# Altium schematic sheet DDR3-RAM.SchDoc(DDR3-RAM)
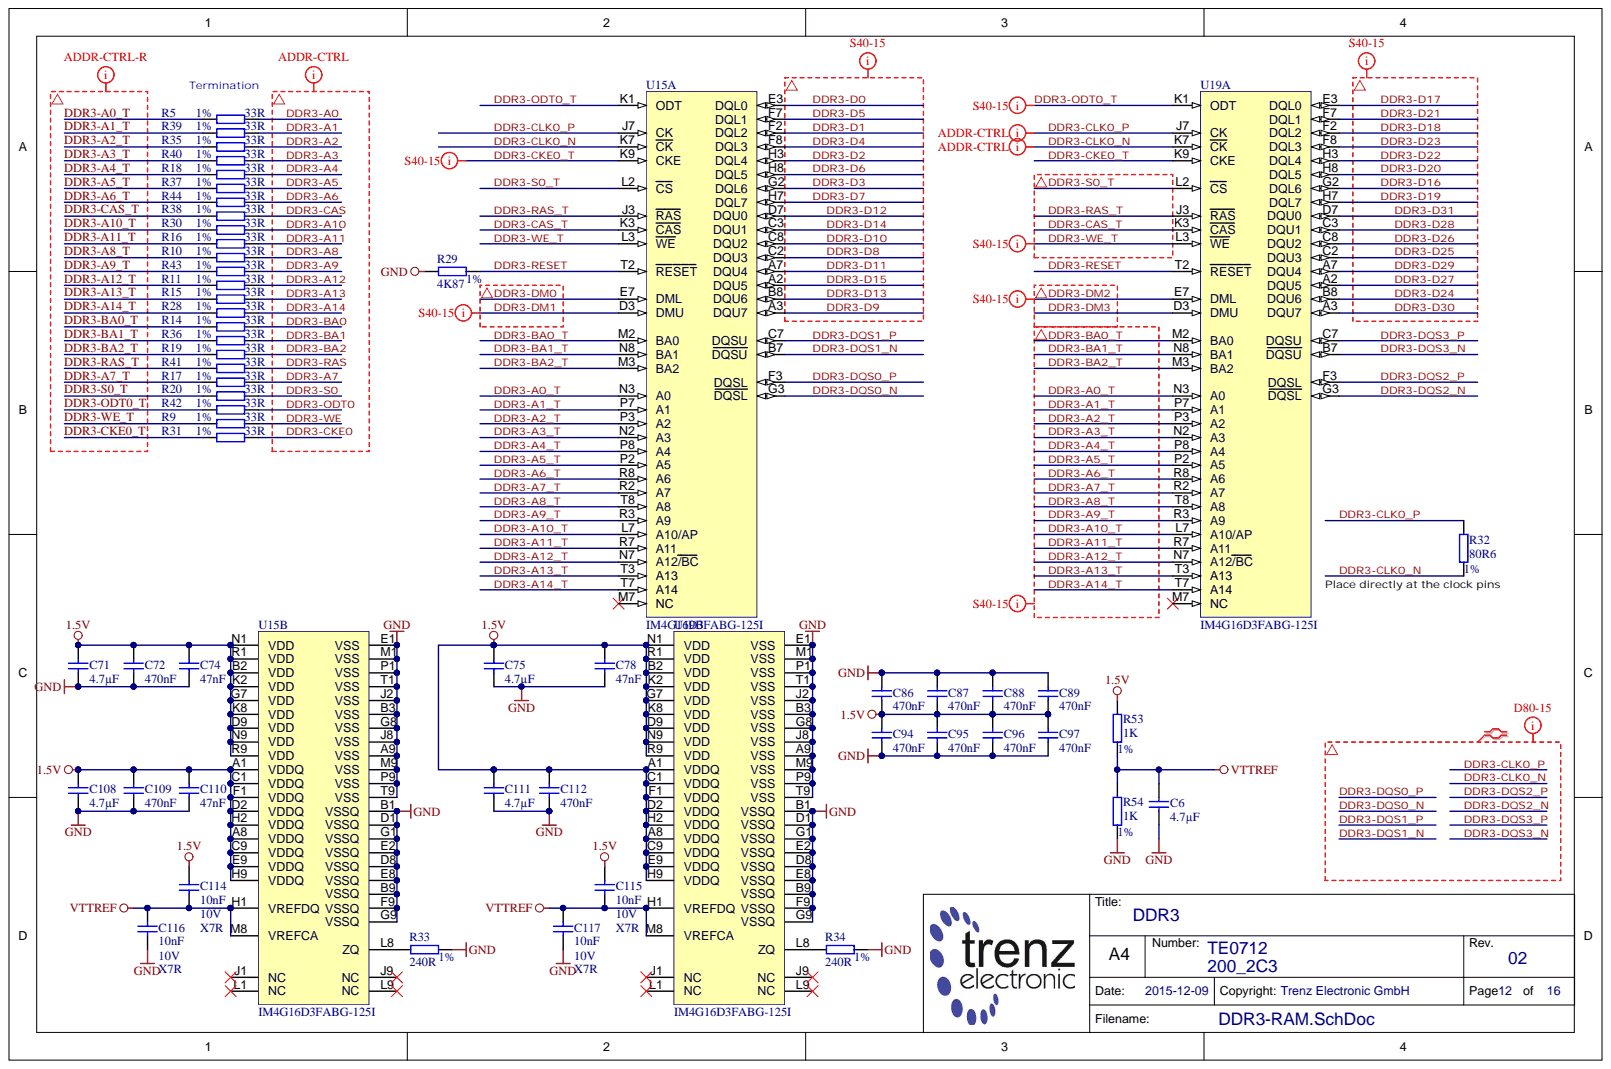
[diagram p0: DDR3-RAM.SchDoc]

## Parts
C6  4.7µF  [CAPC1005X60N]
C71  4.7µF  [CAPC1005X60N]
C72  470nF  [CAPC0603X33N]
C74  47nF  [CAPC0603X33N]
C75  4.7µF  [CAPC1005X60N]
C78  47nF  [CAPC0603X33N]
C86  470nF  [CAPC0603X33N]
C87  470nF  [CAPC0603X33N]
C88  470nF  [CAPC0603X33N]
C89  470nF  [CAPC0603X33N]
C94  470nF  [CAPC0603X33N]
C95  470nF  [CAPC0603X33N]
C96  470nF  [CAPC0603X33N]
C97  470nF  [CAPC0603X33N]
C108  4.7µF  [CAPC1005X60N]
C109  470nF  [CAPC0603X33N]
C110  47nF  [CAPC0603X33N]
C111  4.7µF  [CAPC1005X60N]
C112  470nF  [CAPC0603X33N]
C114  10nF  Voltage: 10V  [CAPC0603X33N]
C115  10nF  Voltage: 10V  [CAPC0603X33N]
C116  10nF  Technology: X7R  Voltage: 10V  [CAPC0603X33N]
C117  10nF  Technology: X7R  Voltage: 10V  [CAPC0603X33N]
R5  33R  Tolerance: 1%  [RESC0603X26N]
R9  33R  Tolerance: 1%  [RESC0603X26N]
R10  33R  Tolerance: 1%  [RESC0603X26N]
R11  33R  Tolerance: 1%  [RESC0603X26N]
R14  33R  Tolerance: 1%  [RESC0603X26N]
R15  33R  Tolerance: 1%  [RESC0603X26N]
R16  33R  Tolerance: 1%  [RESC0603X26N]
R17  33R  Tolerance: 1%  [RESC0603X26N]
R18  33R  Tolerance: 1%  [RESC0603X26N]
R19  33R  Tolerance: 1%  [RESC0603X26N]
R20  33R  Tolerance: 1%  [RESC0603X26N]
R28  33R  Tolerance: 1%  [RESC0603X26N]
R29  4K87  Tolerance: 1%  [RESC1005X40N]
R30  33R  Tolerance: 1%  [RESC0603X26N]
R31  33R  Tolerance: 1%  [RESC0603X26N]
R32  80R6  Tolerance: 1%  [RESC1005X40N]
R33  240R  Tolerance: 1%  [RESC1005X40N]
R34  240R  Tolerance: 1%  [RESC1005X40N]
R35  33R  Tolerance: 1%  [RESC0603X26N]
R36  33R  Tolerance: 1%  [RESC0603X26N]
R37  33R  Tolerance: 1%  [RESC0603X26N]
R38  33R  Tolerance: 1%  [RESC0603X26N]
R39  33R  Tolerance: 1%  [RESC0603X26N]
R40  33R  Tolerance: 1%  [RESC0603X26N]
R41  33R  Tolerance: 1%  [RESC0603X26N]
R42  33R  Tolerance: 1%  [RESC0603X26N]
R43  33R  Tolerance: 1%  [RESC0603X26N]
R44  33R  Tolerance: 1%  [RESC0603X26N]
R53  1K  Tolerance: 1%  [RESC1005X40N]
R54  1K  Tolerance: 1%  [RESC1005X40N]
U15  IM4G16D3FABG-125I  [BGA96C80P9X16_910X1360X120]  (parts A,B)
U19  IM4G16D3FABG-125I  [BGA96C80P9X16_910X1360X120]  (parts A,B)

## Nets
1.5V: C71.1 C72.1 C74.1 C75.1 C78.1 C86.2 C87.2 C88.2 C89.2 C94.1 C95.1 C96.1 C97.1 C108.1 C109.1 C110.1 C111.1 C112.1 C114.1 C115.1 R53.1 U15.A1(VDDQ) U15.A8(VDDQ) U15.B2(VDD) U15.C1(VDDQ) U15.C9(VDDQ) U15.D2(VDDQ) U15.D9(VDD) U15.E9(VDDQ) U15.F1(VDDQ) U15.G7(VDD) U15.H2(VDDQ) U15.H9(VDDQ) U15.K2(VDD) U15.K8(VDD) U15.N1(VDD) U15.N9(VDD) U15.R1(VDD) U15.R9(VDD) U19.A1(VDDQ) U19.A8(VDDQ) U19.B2(VDD) U19.C1(VDDQ) U19.C9(VDDQ) U19.D2(VDDQ) U19.D9(VDD) U19.E9(VDDQ) U19.F1(VDDQ) U19.G7(VDD) U19.H2(VDDQ) U19.H9(VDDQ) U19.K2(VDD) U19.K8(VDD) U19.N1(VDD) U19.N9(VDD) U19.R1(VDD) U19.R9(VDD)
GND: C6.2 C71.2 C72.2 C74.2 C75.2 C78.2 C86.1 C87.1 C88.1 C89.1 C94.2 C95.2 C96.2 C97.2 C108.2 C109.2 C110.2 C111.2 C112.2 C116.2 C117.2 R29.1 R33.2 R34.2 R54.2 U15.A9(VSS) U15.B1(VSSQ) U15.B3(VSS) U15.B9(VSSQ) U15.D1(VSSQ) U15.D8(VSSQ) U15.E1(VSS) U15.E2(VSSQ) U15.E8(VSSQ) U15.F9(VSSQ) U15.G1(VSSQ) U15.G8(VSS) U15.G9(VSSQ) U15.J2(VSS) U15.J8(VSS) U15.M1(VSS) U15.M9(VSS) U15.P1(VSS) U15.P9(VSS) U15.T1(VSS) U15.T9(VSS) U19.A9(VSS) U19.B1(VSSQ) U19.B3(VSS) U19.B9(VSSQ) U19.D1(VSSQ) U19.D8(VSSQ) U19.E1(VSS) U19.E2(VSSQ) U19.E8(VSSQ) U19.F9(VSSQ) U19.G1(VSSQ) U19.G8(VSS) U19.G9(VSSQ) U19.J2(VSS) U19.J8(VSS) U19.M1(VSS) U19.M9(VSS) U19.P1(VSS) U19.P9(VSS) U19.T1(VSS) U19.T9(VSS)
VTTREF: C6.1 C114.2 C115.2 C116.1 C117.1 R53.2 R54.1 U15.H1(VREFDQ) U15.M8(VREFCA) U19.H1(VREFDQ) U19.M8(VREFCA)
DDR3-A0: R5.2
DDR3-A0_T: R5.1 U15.N3(A0) U19.N3(A0)
DDR3-A1: R39.2
DDR3-A1_T: R39.1 U15.P7(A1) U19.P7(A1)
DDR3-A2: R35.2
DDR3-A2_T: R35.1 U15.P3(A2) U19.P3(A2)
DDR3-A3: R40.2
DDR3-A3_T: R40.1 U15.N2(A3) U19.N2(A3)
DDR3-A4: R18.2
DDR3-A4_T: R18.1 U15.P8(A4) U19.P8(A4)
DDR3-A5: R37.2
DDR3-A5_T: R37.1 U15.P2(A5) U19.P2(A5)
DDR3-A6: R44.2
DDR3-A6_T: R44.1 U15.R8(A6) U19.R8(A6)
DDR3-A7: R17.2
DDR3-A7_T: R17.1 U15.R2(A7) U19.R2(A7)
DDR3-A8: R10.2
DDR3-A8_T: R10.1 U15.T8(A8) U19.T8(A8)
DDR3-A9: R43.2
DDR3-A9_T: R43.1 U15.R3(A9) U19.R3(A9)
DDR3-A10: R30.2
DDR3-A10_T: R30.1 U15.L7(A10/AP) U19.L7(A10/AP)
DDR3-A11: R16.2
DDR3-A11_T: R16.1 U15.R7(A11) U19.R7(A11)
DDR3-A12: R11.2
DDR3-A12_T: R11.1 U15.N7(A12/BC) U19.N7(A12/BC)
DDR3-A13: R15.2
DDR3-A13_T: R15.1 U15.T3(A13) U19.T3(A13)
DDR3-A14: R28.2
DDR3-A14_T: R28.1 U15.T7(A14) U19.T7(A14)
DDR3-BA0: R14.2
DDR3-BA0_T: R14.1 U15.M2(BA0) U19.M2(BA0)
DDR3-BA1: R36.2
DDR3-BA1_T: R36.1 U15.N8(BA1) U19.N8(BA1)
DDR3-BA2: R19.2
DDR3-BA2_T: R19.1 U15.M3(BA2) U19.M3(BA2)
DDR3-CAS: R38.2
DDR3-CAS_T: R38.1 U15.K3(CAS) U19.K3(CAS)
DDR3-CKE0: R31.2
DDR3-CKE0_T: R31.1 U15.K9(CKE) U19.K9(CKE)
DDR3-CLK0_N: R32.1 U15.K7(CK) U19.K7(CK)
DDR3-CLK0_P: R32.2 U15.J7(CK) U19.J7(CK)
DDR3-D0: U15.E3(DQL0)
DDR3-D1: U15.F2(DQL2)
DDR3-D2: U15.H3(DQL4)
DDR3-D3: U15.G2(DQL6)
DDR3-D4: U15.F8(DQL3)
DDR3-D5: U15.F7(DQL1)
DDR3-D6: U15.H8(DQL5)
DDR3-D7: U15.H7(DQL7)
DDR3-D8: U15.C2(DQU3)
DDR3-D9: U15.A3(DQU7)
DDR3-D10: U15.C8(DQU2)
DDR3-D11: U15.A7(DQU4)
DDR3-D12: U15.D7(DQU0)
DDR3-D13: U15.B8(DQU6)
DDR3-D14: U15.C3(DQU1)
DDR3-D15: U15.A2(DQU5)
DDR3-D16: U19.G2(DQL6)
DDR3-D17: U19.E3(DQL0)
DDR3-D18: U19.F2(DQL2)
DDR3-D19: U19.H7(DQL7)
DDR3-D20: U19.H8(DQL5)
DDR3-D21: U19.F7(DQL1)
DDR3-D22: U19.H3(DQL4)
DDR3-D23: U19.F8(DQL3)
DDR3-D24: U19.B8(DQU6)
DDR3-D25: U19.C2(DQU3)
DDR3-D26: U19.C8(DQU2)
DDR3-D27: U19.A2(DQU5)
DDR3-D28: U19.C3(DQU1)
DDR3-D29: U19.A7(DQU4)
DDR3-D30: U19.A3(DQU7)
DDR3-D31: U19.D7(DQU0)
DDR3-DM0: U15.E7(DML)
DDR3-DM1: U15.D3(DMU)
DDR3-DM2: U19.E7(DML)
DDR3-DM3: U19.D3(DMU)
DDR3-DQS0_N: U15.G3(DQSL)
DDR3-DQS0_P: U15.F3(DQSL)
DDR3-DQS1_N: U15.B7(DQSU)
DDR3-DQS1_P: U15.C7(DQSU)
DDR3-DQS2_N: U19.G3(DQSL)
DDR3-DQS2_P: U19.F3(DQSL)
DDR3-DQS3_N: U19.B7(DQSU)
DDR3-DQS3_P: U19.C7(DQSU)
DDR3-ODT0: R42.2
DDR3-ODT0_T: R42.1 U15.K1(ODT) U19.K1(ODT)
DDR3-RAS: R41.2
DDR3-RAS_T: R41.1 U15.J3(RAS) U19.J3(RAS)
DDR3-RESET: R29.2 U15.T2(RESET) U19.T2(RESET)
DDR3-S0: R20.2
DDR3-S0_T: R20.1 U15.L2(CS) U19.L2(CS)
DDR3-WE: R9.2
DDR3-WE_T: R9.1 U15.L3(WE) U19.L3(WE)
NetR33_1: R33.1 U15.L8(ZQ)
NetR34_1: R34.1 U19.L8(ZQ)
N00661:
N00662:
N00663:
N00664:
N00665:
N00666:
N00667:

Not connected: U15 J1(NC) J9(NC) L1(NC) L9(NC) M7(NC); U19 J1(NC) J9(NC) L1(NC) L9(NC) M7(NC)

## Library data of the parts
C6 C71 C75 C108 C111 = 24719: KON 4.7µF 6.3V X5R SMD 20%; Database Table Name: C_1005_0402; Mixed: SMT; Preferred: Yes; Value: 4.7u; Voltage: 6.3; AN: 24719; Case: 1005 (0402); Component Kind: Standard; Library Name: TE.DbLib; Technology: X5R; Tolerance: 20%
C72 C86-C89 C94-C97 C109 C112 = 24921: KON 470nF X5R 6.3V SMD 20%; Database Table Name: C_0603_0201; Mixed: SMT; Preferred: Yes; Value: 470n; AN: 24921; Case: 0603 (0201); Component Kind: Standard; Library Name: TE.DbLib; Technology: X5R; Tolerance: 20%; Voltage: 6.3V
C74 C78 C110 = 24924: KON 47nF X5R 6.3V SMD 10%; Database Table Name: C_0603_0201; Mixed: SMT; Preferred: Yes; Value: 47n; AN: 24924; Case: 0603 (0201); Component Kind: Standard; Library Name: TE.DbLib; Technology: X5R; Tolerance: 10%; Voltage: 6.3V
C114-C117 = 26312: KON 10nF X7R 10V SMD 10%; Database Table Name: C_0603_0201; H-ART: GRM033R71A103KA01D; Mixed: SMT; Preferred: Yes; Value: 10n; AN: 26312; Case: 0603 (0201); Component Kind: Standard; Library Name: TE.DbLib; Tolerance: 10%
  C114 C115: Technology: X7R
R5 R9-R11 R14-R20 R28 R30 R31 R35-R44 = 25021: WID SMD 33R 1% 0,05W; Database Table Name: R_0603_0201; Mixed: SMT; Preferred: Yes; Value: 33; AN: 25021; Case: 0603 (0201); Component Kind: Standard; Library Name: TE.DbLib; HelpURL: B:\AD\adlib\datasheet\R0201
R29 = 25921: WID SMD 4K87 1% 0,063W; Database Table Name: R_1005_0402; Mixed: SMT; Preferred: Yes; Value: 4.87k; AN: 25921; Case: 1005 (0402); Component Kind: Standard; Library Name: TE.DbLib
R32 = 25100: WID SMD 80R6 1% 0,063W; Database Table Name: R_1005_0402; H-ART: RC0402FR-0780R6L; Mixed: SMT; Preferred: Yes; Value: 80.6; AN: 25100; Case: 1005 (0402); Component Kind: Standard; Library Name: TE.DbLib
R33 R34 = 23661: WID SMD 240R 1% 0,063W; Database Table Name: R_1005_0402; H-ART: RC0402FR-07240RL; Mixed: SMT; Preferred: Yes; Value: 240; AN: 23661; Case: 1005 (0402); Component Kind: Standard; Library Name: TE.DbLib
R53 R54 = 22752: WID SMD 1K 1% 0,063W; Database Table Name: R_1005_0402; H-ART: RC1005F102CS; Mixed: SMT; Preferred: Yes; AN: 22752; Case: 1005 (0402); Component Kind: Standard; Library Name: TE.DbLib; Value: 1K
U15 U19 = 27202: Database Table Name: DDR; Mixed: SMT; Preferred: Yes; AN: 27202; Case: TFBGA96; Component Kind: Standard; Description: IM4G16D3FABG-125I; H-ART: IM4G16D3FABG-125I; Library Name: TE.DbLib; Trenz-Stock: Yes; HelpURL: B:\AD\adlib\datasheet\IM4GxxD3FABG
